# Altium schematic sheet charger.SchDoc(charger)
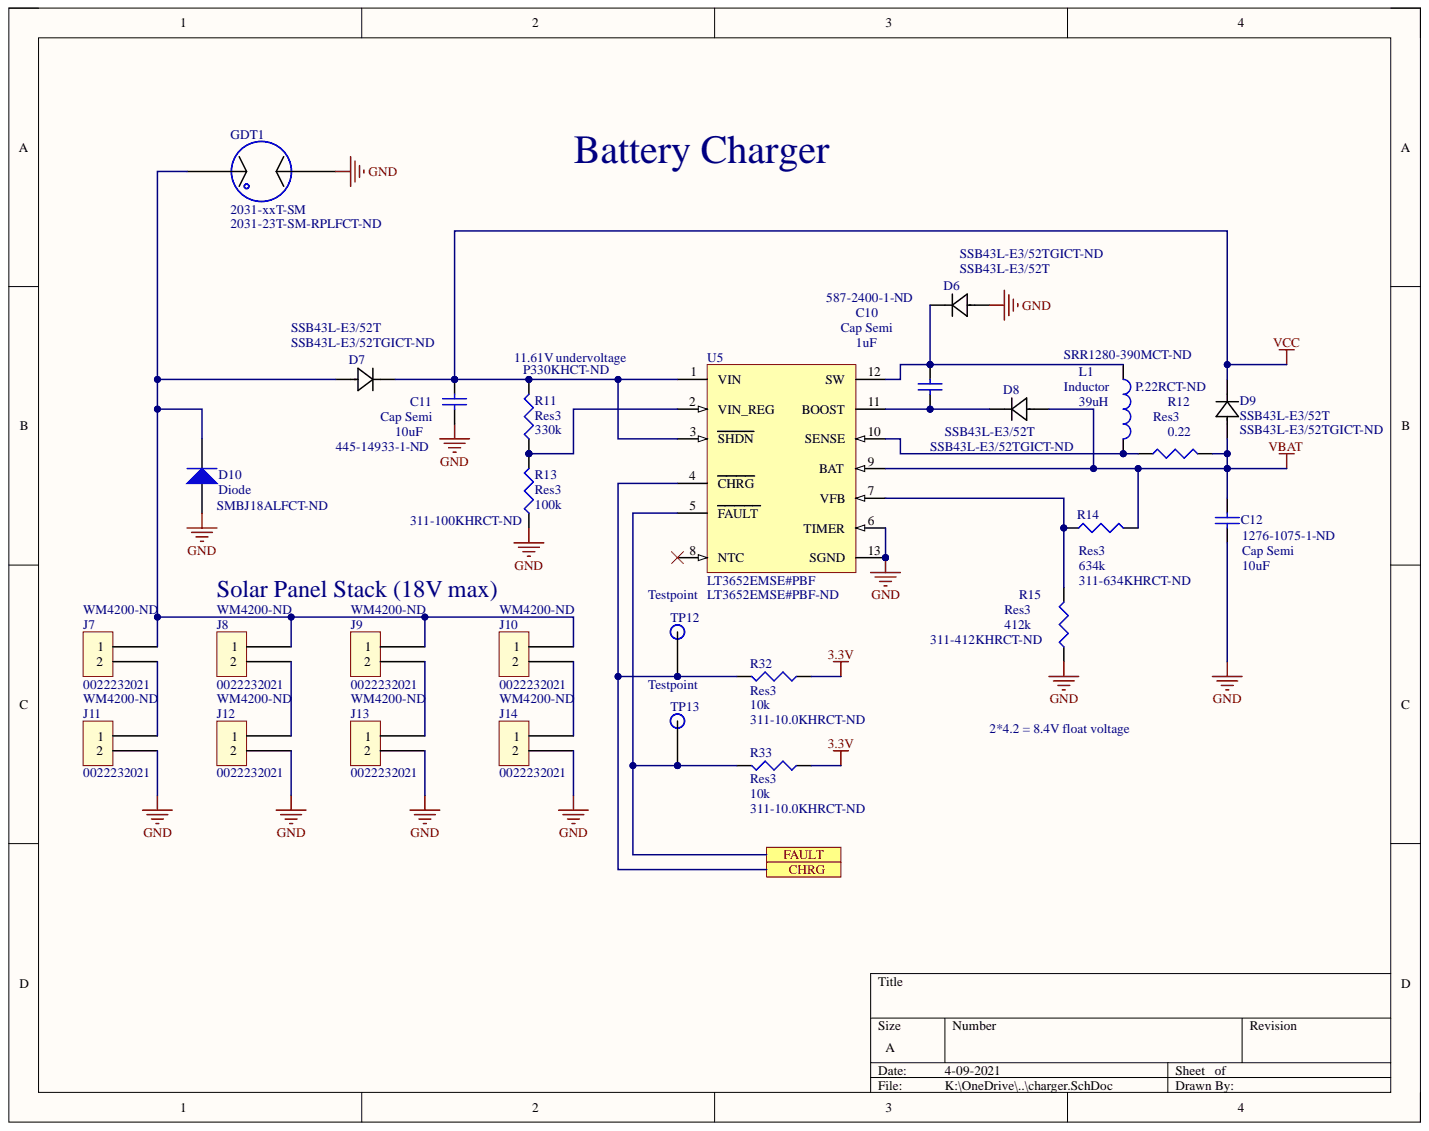
[diagram p0: charger.SchDoc]

## Parts
C10  Cap Semi  value 1uF  [1608[0603]]
C11  Cap Semi  value 10uF  Capacitance: 10uF  Supplier Part Number 1: 445-14933-1-ND  [C1210]
C12  Cap Semi  value 10uF  Capacitance: 10uF  Supplier Part Number 1: 1276-1075-1-ND  [C1206]
D6  SSB43L-E3/52T  [DIODE_DO214AA_00]
D7  SSB43L-E3/52T  Supplier Part Number 1: SSB43L-E3/52TGICT-ND  [DIODE_DO214AA_00]
D8  SSB43L-E3/52T  Supplier Part Number 1: SSB43L-E3/52TGICT-ND  [DIODE_DO214AA_00]
D9  SSB43L-E3/52T  Supplier Part Number 1: SSB43L-E3/52TGICT-ND  [DIODE_DO214AA_00]
D10  Diode  Supplier Part Number 1: SMBJ18ALFCT-ND  [smbj]
GDT1  2031-xxT-SM  Supplier Part Number 1: 2031-23T-SM-RPLFCT-ND  [2031-xxT-SM]
J7  0022232021  Supplier Part Number 1: WM4200-ND  [0022232021]
J8  0022232021  Supplier Part Number 1: WM4200-ND  [0022232021]
J9  0022232021  Supplier Part Number 1: WM4200-ND  [0022232021]
J10  0022232021  Supplier Part Number 1: WM4200-ND  [0022232021]
J11  0022232021  Supplier Part Number 1: WM4200-ND  [0022232021]
J12  0022232021  Supplier Part Number 1: WM4200-ND  [0022232021]
J13  0022232021  Supplier Part Number 1: WM4200-ND  [0022232021]
J14  0022232021  Supplier Part Number 1: WM4200-ND  [0022232021]
L1  Inductor  value 39uH  Inductance: 39uH  Supplier Part Number 1: SRR1280-390MCT-ND  [SRR1280-390M]
R11  Res3  value 330k  Supplier Part Number 1: P330KHCT-ND  [J1-0603]
R12  Res3  value 0.22  [RESC1206]
R13  Res3  value 100k  Supplier Part Number 1: 311-100KHRCT-ND  [J1-0603]
R14  Res3  value 634k  [J1-0603]
R15  Res3  value 412k  Supplier Part Number 1: 311-412KHRCT-ND  [J1-0603]
R32  Res3  value 10k  [J1-0603]
R33  Res3  value 10k  [J1-0603]
TP12  Testpoint  [testpoint]  (no BOM)
TP13  Testpoint  [testpoint]  (no BOM)
U5  LT3652EMSE#PBF  Supplier Part Number 1: LT3652EMSE#PBF-ND  [LT-MSE-12-EDP_M]

## Ports
CHRG, FAULT

## Nets
3.3V: R32.1 R33.1
GND: C11.1 C12.1 D6.2 D10.1 GDT1.1 J11.2 J12.2 J13.2 J14.2 R13.1 R15.1 U5.6(TIMER) U5.13(SGND)
VBAT: C12.2 D8.2 D9.2 R12.2 R14.2 U5.9(BAT)
VCC: C11.2 D7.1 D9.1 R11.2 U5.1(VIN) U5.3(SHDN)
CHRG: R32.2 TP12.1 U5.4(CHRG) ; port CHRG
FAULT: R33.2 TP13.1 U5.5(FAULT) ; port FAULT
NetC10_1: C10.1 D8.1 U5.11(BOOST)
NetC10_2: C10.2 D6.1 L1.1 U5.12(SW)
NetD7_2: D7.2 D10.2 GDT1.2 J7.1 J8.1 J9.1 J10.1
NetJ7_2: J7.2 J11.1
NetJ8_2: J8.2 J12.1
NetJ9_2: J9.2 J13.1
NetJ10_2: J10.2 J14.1
NetL1_2: L1.2 R12.1 U5.10(SENSE)
NetR11_1: R11.1 R13.2 U5.2(VIN_REG)
NetR14_1: R14.1 R15.2 U5.7(VFB)

Not connected: U5 8(NTC)

## Library data of the parts
C10 = Cap Semi: Capacitor (Semiconductor SIM Model); Manufacturer: Taiyo Yuden; Manufacturer Part Number: UMK107BJ105KA-T; Dielectric: X5R; Tolerance: 10%; Voltage Rating: 50V; Capacitance: 1uF; Case Code (Imperial): 0603; Case Code (Metric): 1608; Case/Package: 0603; Component Kind: Standard; ComponentLink1Description: https://datasheet.ciiva.com/5744/1697715-5744913.pdf?src-supplier=Farnell; ComponentLink1URL: https://datasheet.ciiva.com/5744/1697715-5744913.pdf?src-supplier=Farnell; ComponentLink2Description: https://datasheet.ciiva.com/11601/umk107bj105ka-t-ss-11601811.pdf?src-supplier=Avnet; ComponentLink2URL: https://datasheet.ciiva.com/11601/umk107bj105ka-t-ss-11601811.pdf?src-supplier=Avnet; ComponentLink3Description: https://datasheet.ciiva.com/15922/336umk107bj105ka-t-15922611.pdf?src-supplier=Onlinecomponents.com; ComponentLink3URL: https://datasheet.ciiva.com/15922/336umk107bj105ka-t-15922611.pdf?src-supplier=Onlinecomponents.com; ComponentLink4Description: https://datasheet.ciiva.com/134/umk107bj105ka-t-ss-134740.pdf?src-supplier=Digi-Key; ComponentLink4URL: https://datasheet.ciiva.com/134/umk107bj105ka-t-ss-134740.pdf?src-supplier=Digi-Key; ComponentLink5Description: https://datasheet.ciiva.com/30199/43umk107bj105ka-t-30199791.pdf?src-supplier=Onlinecomponents.com; ComponentLink5URL: https://datasheet.ciiva.com/30199/43umk107bj105ka-t-30199791.pdf?src-supplier=Onlinecomponents.com; ComponentLink6Description: https://datasheet.ciiva.com/22694/1697715-22694278.pdf?src-supplier=Element14; ComponentLink6URL: https://datasheet.ciiva.com/22694/1697715-22694278.pdf?src-supplier=Element14; ComponentLink7Description: https://datasheet.ciiva.com/7975/1697715-7975608.pdf?src-supplier=Element14; ComponentLink7URL: https://datasheet.ciiva.com/7975/1697715-7975608.pdf?src-supplier=Element14; ComponentLink8Description: https://datasheet.ciiva.com/7975/mlcc-all-e-7975898.pdf?src-supplier=Element14; ComponentLink8URL: https://datasheet.ciiva.com/7975/mlcc-all-e-7975898.pdf?src-supplier=Element14; ComponentLink9Description: https://datasheet.ciiva.com/5427/mlcc-all-e-541559-5427036.pdf?src-supplier=Mouser; ComponentLink9URL: https://datasheet.ciiva.com/5427/mlcc-all-e-541559-5427036.pdf?src-supplier=Mouser; Contact Plating: Nickel; Depth: 0.8mm; Halogen Free: Halogen Free; Height: 0.8mm; LatestRevisionDate: 29-May-2009; LatestRevisionNote: IPC-7351 Footprint Added.; Length: 1.6mm; Library Name: Miscellaneous Devices.IntLib; Max Operating Temperature: 85°C; Min Operating Temperature: -55°C; Mount: Surface Mount; Number of Pins: 2; Package Quantity: 1; PackageDescription: Chip Capacitor; PackageReference: C1206; Packaging: Tape and Reel; Pricing 1: 1 = 0.21000, 10 = 0.14600, 100 = 0.06880, 500 = 0.04822, 1000 = 0.03993 (USD); Published: 8-Jun-2000; Radiation Hardening: No; REACH SVHC: Unknown; RoHS: Yes; Signal Integrity: Cap Semi; Simulation: CAP; Stock 1: 3042440; Supplier 1: Digi-Key; Supplier Part Number 1: 587-2400-1-ND; Termination: SMD/SMT; Thickness: 0.035inch; Voltage Rating (DC): 50V; Width: 0.031inch; Publisher: Altium Limited
C11 = Cap Semi: Capacitor (Semiconductor SIM Model); Manufacturer: TDK; Manufacturer Part Number: C3225X7R1H106M250AC; Dielectric: X7R; Dielectric Material: Ceramic; Tolerance: 20%; Voltage Rating: 50V; Case Code (Imperial): 1210; Case Code (Metric): 3225; Case/Package: 1210; Component Kind: Standard; ComponentLink1Description: https://datasheet.ciiva.com/24216/cga6p3x7s1h106k250ae-266179-24216129.pdf?src-supplier=Mouser; ComponentLink1URL: https://datasheet.ciiva.com/24216/cga6p3x7s1h106k250ae-266179-24216129.pdf?src-supplier=Mouser; ComponentLink2Description: https://datasheet.ciiva.com/46446/1863333-46446041.pdf?src-supplier=Element14; ComponentLink2URL: https://datasheet.ciiva.com/46446/1863333-46446041.pdf?src-supplier=Element14; ComponentLink3Description: https://datasheet.ciiva.com/46446/1863333-46446042.pdf?src-supplier=Element14; ComponentLink3URL: https://datasheet.ciiva.com/46446/1863333-46446042.pdf?src-supplier=Element14; ComponentLink4Description: http://www.farnell.com/datasheets/2291921.pdf?src-supplier=Farnell; ComponentLink4URL: http://www.farnell.com/datasheets/2291921.pdf?src-supplier=Farnell; ComponentLink5Description: http://www.mouser.com/ds/2/400/tdk_mlcc_commercial_general_en-542463.pdf?src-supplier=Mouser; ComponentLink5URL: http://www.mouser.com/ds/2/400/tdk_mlcc_commercial_general_en-542463.pdf?src-supplier=Mouser; ComponentLink6Description: https://product.tdk.com/info/en/documents/chara_sheet/C3225X7R1H106M250AC.pdf?src-supplier=Digi-Key; ComponentLink6URL: https://product.tdk.com/info/en/documents/chara_sheet/C3225X7R1H106M250AC.pdf?src-supplier=Digi-Key; ComponentLink7Description: http://product.tdk.com/capacitor/mlcc/en/documents/mlcc_commercial_general_en.pdf?src-supplier=Avnet; ComponentLink7URL: http://product.tdk.com/capacitor/mlcc/en/documents/mlcc_commercial_general_en.pdf?src-supplier=Avnet; ComponentLink8Description: http://www.farnell.com/datasheets/1913358.pdf?src-supplier=Element14; ComponentLink8URL: http://www.farnell.com/datasheets/1913358.pdf?src-supplier=Element14; ComponentLink9Description: http://www.farnell.com/datasheets/2291921.pdf?src-supplier=Newark; ComponentLink9URL: http://www.farnell.com/datasheets/2291921.pdf?src-supplier=Newark; Height: 2.5mm; Lead Free: Lead Free; Length: 0.126inch; Library Name: Miscellaneous Devices.IntLib; Max Operating Temperature: 125°C; Min Operating Temperature: -55°C; Mount: Surface Mount; Package Quantity: 1000; Packaging: Tape and Reel; Pricing 1: 1=1.57000, 10=1.24200, 100=0.93150, 500=0.70380 (USD); REACH SVHC: No SVHC; RoHS: Yes; Signal Integrity: Cap Semi; Simulation: CAP; Stock 1: 251613; Supplier 1: Digi-Key; Termination: SMD/SMT; Thickness: 0.11inch; Voltage Rating (DC): 50V; Weight: 0.000564oz; Width: 0.098inch
C12 = Cap Semi: Capacitor (Semiconductor SIM Model); Manufacturer: Samsung; Manufacturer Part Number: CL31A106KAHNNNE; Dielectric: X5R; Material: Ceramic; Tolerance: 10%; Voltage: 25V; Case Code (Imperial): 1206; Case Code (Metric): 3216; Case/Package: 1206; Component Kind: Standard; ComponentLink1Description: https://datasheet.ciiva.com/25710/cl31a106kahnnne-25710837.pdf?src-supplier=Digi-Key; ComponentLink1URL: https://datasheet.ciiva.com/25710/cl31a106kahnnne-25710837.pdf?src-supplier=Digi-Key; ComponentLink2Description: https://datasheet.ciiva.com/15195/getdatasheetpartid-355210-15195990.pdf?src-supplier=Verical; ComponentLink2URL: https://datasheet.ciiva.com/15195/getdatasheetpartid-355210-15195990.pdf?src-supplier=Verical; ComponentLink3Description: https://datasheet.ciiva.com/29201/452519-da-01-en-vs-kondensator-1206-29201124.pdf?src-supplier=Conrad; ComponentLink3URL: https://datasheet.ciiva.com/29201/452519-da-01-en-vs-kondensator-1206-29201124.pdf?src-supplier=Conrad; Contact Plating: Tin; Depth: 1.6mm; Height: 1.6mm; LatestRevisionDate: 29-May-2009; LatestRevisionNote: IPC-7351 Footprint Added.; Lead Free: Lead Free; Length: 3.2mm; Library Name: Miscellaneous Devices.IntLib; Max Operating Temperature: 85°C; Min Operating Temperature: -55°C; Mount: Surface Mount; PackageDescription: Chip Capacitor; PackageReference: C1206; Packaging: Tape and Reel; Pricing 1: 1 = 0.22000, 10 = 0.15000, 100 = 0.07600, 500 = 0.05200, 1000 = 0.04600 (USD); Published: 8-Jun-2000; Radiation Hardening: No; RoHS: Yes; Signal Integrity: Cap Semi; Simulation: CAP; Stock 1: 1234131; Supplier 1: Digi-Key; Thickness: 0.071inch; Voltage Rating: 25V; Voltage Rating (DC): 25V; Width: 0.063inch; Publisher: Altium Limited
D6-D9 = SSB43L-E3/52T: Manufacturer: Vishay Semiconductors; Average Rectified Current: 4A; Element Configuration: Single; Forward Voltage: 450mV; Max Forward Surge Current (Ifsm): 100A; Max Repetitive Reverse Voltage (Vrrm): 30V; Max Reverse Leakage Current: 600uA; Polarity: Standard; Case/Package: SMB; Component Kind: Standard; ComponentLink1Description: https://datasheet.ciiva.com/7962/64541-7962449.pdf?src-supplier=Element14; ComponentLink1URL: https://datasheet.ciiva.com/7962/64541-7962449.pdf?src-supplier=Element14; ComponentLink2Description: https://datasheet.ciiva.com/14634/0900766b80dd0508-14634162.pdf?src-supplier=Allied+Electronics; ComponentLink2URL: https://datasheet.ciiva.com/14634/0900766b80dd0508-14634162.pdf?src-supplier=Allied+Electronics; ComponentLink3Description: https://datasheet.ciiva.com/4245/ssb43l-4245635.pdf?src-supplier=Arrow; ComponentLink3URL: https://datasheet.ciiva.com/4245/ssb43l-4245635.pdf?src-supplier=Arrow; ComponentLink4Description: https://datasheet.ciiva.com/15224/getdatasheetpartid-99263-15224680.pdf?src-supplier=Verical; ComponentLink4URL: https://datasheet.ciiva.com/15224/getdatasheetpartid-99263-15224680.pdf?src-supplier=Verical; ComponentLink5Description: https://datasheet.ciiva.com/18434/70217334-18434422.pdf?src-supplier=Allied+Electronics; ComponentLink5URL: https://datasheet.ciiva.com/18434/70217334-18434422.pdf?src-supplier=Allied+Electronics; Contact Plating: Tin; Current Rating: 4A; Description: SSB43L-E3/52T; Forward Current: 4A; Height: 0.095inch; Lead Free: Lead Free; Length: 4.7mm; Library Name: vishay.IntLib; Manufacturer Part Number: SSB43L-E3/52T; Max Operating Temperature: 150°C; Max Reverse Voltage (DC): 30V; Max Surge Current: 100A; Min Operating Temperature: -65°C; Mount: Surface Mount; Number of Pins: 2; Output Current: 4A; Package Quantity: 1; Packaging: Tape and Reel; Peak Non-Repetitive Surge Current: 100A; Peak Reverse Current: 600uA; Pricing 1: 1 = 0.58000, 10 = 0.49300, 100 = 0.36800 (USD); Radiation Hardening: No; REACH SVHC: Unknown; RoHS: Yes; Stock 1: 195068; Supplier 1: Digi-Key; Voltage Rating (DC): 30V; Width: 3.8mm
  D6: Supplier Part Number 1: SSB43L-E3/52TGICT-ND
D10 = Diode: Default Diode; Manufacturer: Littelfuse; Manufacturer Part Number: SMBJ18A; Breakdown Voltage: 20V; Clamping Voltage: 29.2V; Direction: Unidirectional; Element Configuration: Single; Leakage Current: 1uA; Max Breakdown Voltage: 22.1V; Max Power Dissipation: 5W; Max Surge Current: 20.6A; Number of Channels: 1; Operating Supply Voltage: 18V; Peak Pulse Power: 600W; Power Line Protection: No; Test Current: 1mA; Case/Package: SMB; Component Kind: Standard; ComponentLink1Description: http://www.bourns.com/data/global/pdfs/SMBJ.pdf; ComponentLink1URL: http://www.bourns.com/data/global/pdfs/SMBJ.pdf; ComponentLink2Description: https://datasheet.ciiva.com/25624/0900766b810f4eeb-25624683.pdf?src-supplier=RSComponents; ComponentLink2URL: https://datasheet.ciiva.com/25624/0900766b810f4eeb-25624683.pdf?src-supplier=RSComponents; ComponentLink3Description: https://datasheet.ciiva.com/15143/getdatasheetpartid-158332-15143823.pdf?src-supplier=Verical; ComponentLink3URL: https://datasheet.ciiva.com/15143/getdatasheetpartid-158332-15143823.pdf?src-supplier=Verical; ComponentLink4Description: https://datasheet.ciiva.com/331/littelfuse-tvs-diode-smbj-datasheet-pdf-331232.pdf?src-supplier=Digi-Key; ComponentLink4URL: https://datasheet.ciiva.com/331/littelfuse-tvs-diode-smbj-datasheet-pdf-331232.pdf?src-supplier=Digi-Key; ComponentLink5Description: https://datasheet.ciiva.com/8062/littelfuse-tvs-diode-smbj-8062311.pdf?src-supplier=Element14; ComponentLink5URL: https://datasheet.ciiva.com/8062/littelfuse-tvs-diode-smbj-8062311.pdf?src-supplier=Element14; ComponentLink6Description: https://datasheet.ciiva.com/331/littelfuse-tvs-diode-smbj-datasheet-pdf-331230.pdf?src-supplier=Digi-Key; ComponentLink6URL: https://datasheet.ciiva.com/331/littelfuse-tvs-diode-smbj-datasheet-pdf-331230.pdf?src-supplier=Digi-Key; ComponentLink7Description: https://datasheet.ciiva.com/331/littelfuse-tvs-diode-smbj-datasheet-pdf-331231.pdf?src-supplier=Digi-Key; ComponentLink7URL: https://datasheet.ciiva.com/331/littelfuse-tvs-diode-smbj-datasheet-pdf-331231.pdf?src-supplier=Digi-Key; ComponentLink8Description: https://datasheet.ciiva.com/16354/littelfuse-tvs-diode-smbj-16354943.pdf?src-supplier=Future+Electronics; ComponentLink8URL: https://datasheet.ciiva.com/16354/littelfuse-tvs-diode-smbj-16354943.pdf?src-supplier=Future+Electronics; Composition: Zener; Height: 2.237mm; Lead Free: Lead Free; Length: 4.57mm; Library Name: Miscellaneous Devices.IntLib; Max Operating Temperature: 150°C; Max Reverse Leakage Current: 1uA; Min Breakdown Voltage: 20V; Min Operating Temperature: -65°C; Mount: Surface Mount; Number of Elements: 1; Number of Pins: 2; Number of Unidirectional Channels: 1; Package Quantity: 3000; Packaging: Tape and Reel; Peak Pulse Current: 20.6A; Polarity: Unidirectional; Power Dissipation: 600W; Pricing 1: 1 = 0.53000, 10 = 0.41600, 25 = 0.35080, 100 = 0.28550, 250 = 0.23660, 500 = 0.19580, 1000 = 0.14685 (USD); Radiation Hardening: No; REACH SVHC: Unknown; Reverse Breakdown Voltage: 20V; Reverse Standoff Voltage: 18V; RoHS: Yes; Signal Integrity: Diode; Simulation: DIODE; Stock 1: 15394; Supplier 1: Digi-Key; Termination: SMD/SMT; Voltage Rating (DC): 18V; Width: 3.94mm
GDT1 = 2031-xxT-SM: Gas discharge tube; Manufacturer: Bourns Inc.; Manufacturer Part Number: 2031-23T-SM-RPLF; Fail Short: No; Impulse Discharge Current (8/20µs): 150A (10/700µs); Number of Poles: 2; Voltage - DC Spark Over (Nom): 185V; Category: Circuit Protection; Component Kind: Standard; ComponentLink1Description: http://www.bourns.com/data/global/pdfs/2031-xxT.pdf; ComponentLink1URL: http://www.bourns.com/data/global/pdfs/2031-xxT.pdf; Current - AC Discharge (Nom): -; Library Name: bourns.IntLib; Mounting Type: Surface Mount; Package / Case: 2-SMD Cylinder Square End; Packaging: Cut Tape (CT); Pricing 1: 1=1.44, 10=1.307, 25=1.2644, 50=1.0836, 100=0.903, 250=0.8772, 500=0.7439 (USD); RoHS: RoHS Compliant; Stock 1: 847; Supplier 1: Digi-Key; Tolerance: -
J7-J14 = 0022232021: Manufacturer: Molex; Approvals: UL; Contact Resistance: 10mR; Current Rating: 4A; Gender: Male; Glow Wire Compliant: Non-compliant; Insulation Resistance: 500GR; Number of Circuits: 2; Pitch: 2.54mm; Voltage Rating: 250V; Color: White; Component Kind: Standard; ComponentLink1Description: https://datasheet.ciiva.com/19454/232266-19454304.pdf?src-supplier=Jameco; ComponentLink1URL: https://datasheet.ciiva.com/19454/232266-19454304.pdf?src-supplier=Jameco; ComponentLink2Description: https://datasheet.ciiva.com/22683/1834442-22683561.pdf?src-supplier=Element14; ComponentLink2URL: https://datasheet.ciiva.com/22683/1834442-22683561.pdf?src-supplier=Element14; ComponentLink3Description: https://datasheet.ciiva.com/5150/0022232021-pcb-headers-227096-5150681.pdf?src-supplier=Mouser; ComponentLink3URL: https://datasheet.ciiva.com/5150/0022232021-pcb-headers-227096-5150681.pdf?src-supplier=Mouser; ComponentLink4Description: https://datasheet.ciiva.com/11746/0900766b80d8f4d1-11746058.pdf?src-supplier=RSComponents; ComponentLink4URL: https://datasheet.ciiva.com/11746/0900766b80d8f4d1-11746058.pdf?src-supplier=RSComponents; ComponentLink5Description: https://datasheet.ciiva.com/30253/114200022232021-pcb-headers-30253002.pdf?src-supplier=Onlinecomponents.com; ComponentLink5URL: https://datasheet.ciiva.com/30253/114200022232021-pcb-headers-30253002.pdf?src-supplier=Onlinecomponents.com; ComponentLink6Description: https://datasheet.ciiva.com/16658/0022232021-pcb-headers-16658167.pdf?src-supplier=Avnet+Europe; ComponentLink6URL: https://datasheet.ciiva.com/16658/0022232021-pcb-headers-16658167.pdf?src-supplier=Avnet+Europe; ComponentLink7Description: https://datasheet.ciiva.com/18453/70190606-18453638.pdf?src-supplier=Allied+Electronics; ComponentLink7URL: https://datasheet.ciiva.com/18453/70190606-18453638.pdf?src-supplier=Allied+Electronics; ComponentLink8Description: https://datasheet.ciiva.com/9468/0022232021-pcb-headers-9468476.pdf?src-supplier=Avnet; ComponentLink8URL: https://datasheet.ciiva.com/9468/0022232021-pcb-headers-9468476.pdf?src-supplier=Avnet; Contact Material: Brass; Contact Plating: Tin; Depth: 6.35mm; Description: 0022232021; Flammability Rating: UL94 V-0; Halogen Free: Low Halogen; Height: 10.67mm; Housing Color: White; Housing Material: Nylon; Lead Free: Lead Free; Length: 5.08mm; Library Name: molex.IntLib; Manufacturer Part Number: 22-23-2021; Max Current Rating: 4A; Max Operating Temperature: 75°C; Min Operating Temperature: 0°C; Mount: Through Hole; Number of Contacts: 2; Number of Positions: 2; Number of Rows: 1; Orientation: Straight; Package Quantity: 3000; Packaging: Bulk; Pricing 1: 1=0.17000, 10=0.16100, 100=0.11150, 500=0.09438, 1000=0.07722, 5000=0.06864, 10000=0.06221 (USD); Radiation Hardening: No; REACH SVHC: Unknown; RoHS: Yes; Stock 1: 76471; Supplier 1: Digi-Key; Termination: Through Hole; Voltage Rating (DC): 250V
L1 = Inductor: Manufacturer: Bourns; Code_IEC: 1005; Core Material: Ferrite; Current Rating: 3.2A; DC Resistance (DCR): 70mR; Frequency: 8.5MHz; Inductance Tolerance: 20%; Material: Steel; Q Factor: 24; Saturation Current: 3.1A; Shielding: Shielded; Test Frequency: 2.52MHz; Case/Package: Nonstandard; Code_JEITA: 0402; Component Kind: Standard; ComponentLink1Description: https://datasheet.ciiva.com/1062/srr1280-1062601.pdf?src-supplier=Digi-Key; ComponentLink1URL: https://datasheet.ciiva.com/1062/srr1280-1062601.pdf?src-supplier=Digi-Key; ComponentLink2Description: https://datasheet.ciiva.com/1062/srr1280-1062602.pdf?src-supplier=Digi-Key; ComponentLink2URL: https://datasheet.ciiva.com/1062/srr1280-1062602.pdf?src-supplier=Digi-Key; ComponentLink3Description: https://datasheet.ciiva.com/48684/srr1280-48684942.pdf?src-supplier=Avnet; ComponentLink3URL: https://datasheet.ciiva.com/48684/srr1280-48684942.pdf?src-supplier=Avnet; Composition: Wirewound; DC Current: 3.2A; Depth: 12.8mm; Description: Inductor; Height: 7.5mm; Height - Seated (Max): 0.315inch; LatestRevisionDate: 29-May-2009; LatestRevisionNote: IPC-7351 Footprint Added.; Length: 12.5mm; Library Name: Miscellaneous Devices.IntLib; Manufacturer Part Number: SRR1280-390M; Max DC Current: 3.2A; Max Operating Temperature: 125°C; Military Standard: Not; Min Operating Temperature: -40°C; Mount: Surface Mount; Number of Pins: 2; Package Quantity: 400; PackageDescription: Chip Inductor; PackageReference: 0402-A; Packaging: Tape and Reel; Pricing 1: 1 = 1.25000, 10 = 1.15900, 100 = 0.83780 (USD); Published: 8-Jun-2000; REACH SVHC: No SVHC; Resistance: 70MR; RMS Current (Irms): 3.2A; RoHS: Yes; Self Resonant Frequency: 8.5MHz; Signal Integrity: Inductor; Simulation: INDUCTOR; Stock 1: 6267; Supplier 1: Digi-Key; Termination: SMD/SMT; Tolerance: 20%; Width: 12.5mm; Publisher: Altium Limited
R11 = Res3: Resistor; Manufacturer: Panasonic; Manufacturer Part Number: ERJ-3EKF3303V; Max Power Dissipation: 100mW; Resistance: 330kR; Temperature Coefficient: 100ppm/°C; Tolerance: 1%; Voltage Rating: 75V; Case Code (Imperial): 0603; Case Code (Metric): 1608; Case/Package: 0603; Component Kind: Standard; ComponentLink1Description: https://datasheet.ciiva.com/17206/aoa0000ce2-17206262.pdf?src-supplier=Avnet+Europe; ComponentLink1URL: https://datasheet.ciiva.com/17206/aoa0000ce2-17206262.pdf?src-supplier=Avnet+Europe; ComponentLink2Description: https://datasheet.ciiva.com/22673/1678782-22673287.pdf?src-supplier=Element14; ComponentLink2URL: https://datasheet.ciiva.com/22673/1678782-22673287.pdf?src-supplier=Element14; ComponentLink3Description: https://datasheet.ciiva.com/16766/70260748-16766613.pdf?src-supplier=Allied+Electronics; ComponentLink3URL: https://datasheet.ciiva.com/16766/70260748-16766613.pdf?src-supplier=Allied+Electronics; ComponentLink4Description: https://datasheet.ciiva.com/7836/aoa0000ce2-7836502.pdf?src-supplier=Element14; ComponentLink4URL: https://datasheet.ciiva.com/7836/aoa0000ce2-7836502.pdf?src-supplier=Element14; ComponentLink5Description: https://datasheet.ciiva.com/24164/aoa0000ce2-24164038.pdf?src-supplier=Mouser; ComponentLink5URL: https://datasheet.ciiva.com/24164/aoa0000ce2-24164038.pdf?src-supplier=Mouser; ComponentLink6Description: https://datasheet.ciiva.com/9117/aoa0000ce2-9117145.pdf?src-supplier=Avnet; ComponentLink6URL: https://datasheet.ciiva.com/9117/aoa0000ce2-9117145.pdf?src-supplier=Avnet; ComponentLink7Description: https://datasheet.ciiva.com/16781/70260748-16781705.pdf?src-supplier=Allied+Electronics; ComponentLink7URL: https://datasheet.ciiva.com/16781/70260748-16781705.pdf?src-supplier=Allied+Electronics; ComponentLink8Description: https://datasheet.ciiva.com/9182/aoa0000ce2-9182954.pdf?src-supplier=Avnet; ComponentLink8URL: https://datasheet.ciiva.com/9182/aoa0000ce2-9182954.pdf?src-supplier=Avnet; ComponentLink9Description: https://datasheet.ciiva.com/30247/aoa0000ce2-30247322.pdf?src-supplier=Onlinecomponents.com; ComponentLink9URL: https://datasheet.ciiva.com/30247/aoa0000ce2-30247322.pdf?src-supplier=Onlinecomponents.com; ComponentLink10Description: https://datasheet.ciiva.com/22687/1678782-22687435.pdf?src-supplier=Element14; ComponentLink10URL: https://datasheet.ciiva.com/22687/1678782-22687435.pdf?src-supplier=Element14; Composition: Thick Film; Contact Plating: Tin; Depth: 0.8mm; Features: Automotive AEC-Q200; Height: 0.022inch; LatestRevisionDate: 29-May-2009; LatestRevisionNote: IPC-7351 Footprint Added.; Lead Free: Lead Free; Length: 1.6mm; Library Name: Miscellaneous Devices.IntLib; Max Operating Temperature: 155°C; Military Standard: Not; Min Operating Temperature: -55°C; Mount: Surface Mount; Number of Pins: 2; Number of Terminations: 2; Package Quantity: 1; PackageDescription: Chip Resistor; PackageReference: J1-0603; Packaging: Tape and Reel; Power Rating: 100mW; Pricing 1: 1=0.10000, 10=0.06500, 100=0.02640, 1000=0.01186, 2500=0.01029 (USD); Published: 8-Jun-2000; Radiation Hardening: No; REACH SVHC: No SVHC; RoHS: Yes; Signal Integrity: Res; Simulation: RESISTOR; Stock 1: 836713; Supplier 1: Digi-Key; Termination: SMD/SMT; Voltage Rating (DC): 75V; Weight: 7.1E-05oz; Width: 0.8mm; Publisher: Altium Limited
R12 = Res3: Resistor; Manufacturer: Panasonic; Manufacturer Part Number: ERJ-8RQFR22V; Max Power Dissipation: 250mW; Resistance: 220mR; Temperature Coefficient: 250ppm/°C; Tolerance: 1%; Case Code (Imperial): 1206; Case Code (Metric): 3216; Case/Package: 1206; Component Kind: Standard; ComponentLink1Description: https://datasheet.ciiva.com/11818/0900766b80eefa12-11818582.pdf?src-supplier=RSComponents; ComponentLink1URL: https://datasheet.ciiva.com/11818/0900766b80eefa12-11818582.pdf?src-supplier=RSComponents; ComponentLink2Description: https://datasheet.ciiva.com/28548/1790602-28548389.pdf?src-supplier=Element14; ComponentLink2URL: https://datasheet.ciiva.com/28548/1790602-28548389.pdf?src-supplier=Element14; ComponentLink3Description: https://datasheet.ciiva.com/16773/70068852-16773943.pdf?src-supplier=Allied+Electronics; ComponentLink3URL: https://datasheet.ciiva.com/16773/70068852-16773943.pdf?src-supplier=Allied+Electronics; ComponentLink4Description: https://datasheet.ciiva.com/28694/1790602-28694263.pdf?src-supplier=Element14; ComponentLink4URL: https://datasheet.ciiva.com/28694/1790602-28694263.pdf?src-supplier=Element14; ComponentLink5Description: https://datasheet.ciiva.com/24073/aoa0000ce3-72189-24073048.pdf?src-supplier=Mouser; ComponentLink5URL: https://datasheet.ciiva.com/24073/aoa0000ce3-72189-24073048.pdf?src-supplier=Mouser; ComponentLink6Description: https://datasheet.ciiva.com/9184/aoa0000ce3-9184313.pdf?src-supplier=Avnet; ComponentLink6URL: https://datasheet.ciiva.com/9184/aoa0000ce3-9184313.pdf?src-supplier=Avnet; ComponentLink7Description: https://datasheet.ciiva.com/16395/aoa0000ce3-16395226.pdf?src-supplier=Future+Electronics; ComponentLink7URL: https://datasheet.ciiva.com/16395/aoa0000ce3-16395226.pdf?src-supplier=Future+Electronics; ComponentLink8Description: https://datasheet.ciiva.com/17206/aoa0000ce3-17206890.pdf?src-supplier=Avnet+Europe; ComponentLink8URL: https://datasheet.ciiva.com/17206/aoa0000ce3-17206890.pdf?src-supplier=Avnet+Europe; ComponentLink9Description: https://datasheet.ciiva.com/6064/391509-6064715.pdf?src-supplier=Farnell; ComponentLink9URL: https://datasheet.ciiva.com/6064/391509-6064715.pdf?src-supplier=Farnell; ComponentLink10Description: https://datasheet.ciiva.com/16778/70068852-16778907.pdf?src-supplier=Allied+Electronics; ComponentLink10URL: https://datasheet.ciiva.com/16778/70068852-16778907.pdf?src-supplier=Allied+Electronics; ComponentLink11Description: https://datasheet.ciiva.com/30088/6aoa0000ce3-30088699.pdf?src-supplier=Onlinecomponents.com; ComponentLink11URL: https://datasheet.ciiva.com/30088/6aoa0000ce3-30088699.pdf?src-supplier=Onlinecomponents.com; ComponentLink12Description: https://datasheet.ciiva.com/7710/391509-7710844.pdf?src-supplier=Element14; ComponentLink12URL: https://datasheet.ciiva.com/7710/391509-7710844.pdf?src-supplier=Element14; ComponentLink13Description: https://datasheet.ciiva.com/6064/391509-6064717.pdf?src-supplier=Farnell; ComponentLink13URL: https://datasheet.ciiva.com/6064/391509-6064717.pdf?src-supplier=Farnell; ComponentLink14Description: https://datasheet.ciiva.com/31406/1790602-31406415.pdf?src-supplier=Farnell; ComponentLink14URL: https://datasheet.ciiva.com/31406/1790602-31406415.pdf?src-supplier=Farnell; Composition: Thick Film; Contact Plating: Tin; Depth: 1.6mm; Features: Automotive AEC-Q200; Height: 0.6mm; LatestRevisionDate: 29-May-2009; LatestRevisionNote: IPC-7351 Footprint Added.; Lead Free: Lead Free; Length: 3.2mm; Library Name: Miscellaneous Devices.IntLib; Max Operating Temperature: 125°C; Military Standard: Not; Min Operating Temperature: -55°C; Mount: Surface Mount; Number of Pins: 2; Number of Terminations: 2; Package Quantity: 1; PackageDescription: Chip Resistor; PackageReference: J1-0603; Packaging: Tape and Reel; Power Rating: 250mW; Pricing 1: 1 = 0.39000, 10 = 0.28300, 25 = 0.20920, 50 = 0.15420, 100 = 0.11320, 250 = 0.09348, 500 = 0.07708, 1000 = 0.05576 (USD); Published: 8-Jun-2000; Radiation Hardening: No; REACH SVHC: Unknown; RoHS: Yes; Signal Integrity: Res; Simulation: RESISTOR; Stock 1: 127343; Supplier 1: Digi-Key; Supplier Part Number 1: P.22RCT-ND; Termination: SMD/SMT; Width: 1.6mm; Publisher: Altium Limited
R13 = Res3: Resistor; Manufacturer: Yageo; Manufacturer Part Number: RC0603FR-07100KL; Power Rating: 100mW; Resistance: 100kR; Temperature Coefficient: 100ppm/°C; Tolerance: 1%; Voltage Rating: 50V; Working Voltage: 75V; Case Code (Imperial): 0603; Case Code (Metric): 1608; Case/Package: 0603; Component Kind: Standard; ComponentLink1Description: https://datasheet.ciiva.com/11808/0900766b80f96399-11808107.pdf?src-supplier=RSComponents; ComponentLink1URL: https://datasheet.ciiva.com/11808/0900766b80f96399-11808107.pdf?src-supplier=RSComponents; ComponentLink2Description: https://datasheet.ciiva.com/7983/443971-7983593.pdf?src-supplier=Element14; ComponentLink2URL: https://datasheet.ciiva.com/7983/443971-7983593.pdf?src-supplier=Element14; ComponentLink3Description: https://datasheet.ciiva.com/46440/1868787-46440889.pdf?src-supplier=Element14; ComponentLink3URL: https://datasheet.ciiva.com/46440/1868787-46440889.pdf?src-supplier=Element14; ComponentLink4Description: https://datasheet.ciiva.com/7746/1738695-7746234.pdf?src-supplier=Element14; ComponentLink4URL: https://datasheet.ciiva.com/7746/1738695-7746234.pdf?src-supplier=Element14; ComponentLink5Description: https://datasheet.ciiva.com/7746/1738695-7746235.pdf?src-supplier=Element14; ComponentLink5URL: https://datasheet.ciiva.com/7746/1738695-7746235.pdf?src-supplier=Element14; ComponentLink6Description: https://datasheet.ciiva.com/22747/443971-22747516.pdf?src-supplier=Element14; ComponentLink6URL: https://datasheet.ciiva.com/22747/443971-22747516.pdf?src-supplier=Element14; Composition: Thick Film; Depth: 0.8mm; Height: 0.45mm; LatestRevisionDate: 29-May-2009; LatestRevisionNote: IPC-7351 Footprint Added.; Lead Free: Lead Free; Length: 1.6mm; Library Name: Miscellaneous Devices.IntLib; Max Operating Temperature: 155°C; Military Standard: Not; Min Operating Temperature: -55°C; Number of Pins: 2; Number of Terminations: 2; PackageDescription: Chip Resistor; PackageReference: J1-0603; Packaging: Tape and Reel; Pricing 1: 1=0.10000, 10=0.02400, 100=0.00970, 1000=0.00437, 2500=0.00379 (USD); Published: 8-Jun-2000; REACH SVHC: No SVHC; RoHS: Yes; Signal Integrity: Res; Simulation: RESISTOR; Stock 1: 48923364; Supplier 1: Digi-Key; Termination: SMD/SMT; Voltage Rating (DC): 50V; Width: 0.8mm; Publisher: Altium Limited
R14 = Res3: Resistor; Manufacturer: Yageo; Manufacturer Part Number: RC0603FR-07634KL; Max Power Dissipation: 100mW; Resistance: 634kR; Temperature Coefficient: 100ppm/°C; Tolerance: 1%; Value: 634k; Voltage Rating: 50V; Case Code (Imperial): 0603; Case Code (Metric): 1608; Case/Package: 0603; Component Kind: Standard; ComponentLink1Description: https://datasheet.ciiva.com/2455/pyu-r-int-thick-7-2455320.pdf?src-supplier=Digi-Key; ComponentLink1URL: https://datasheet.ciiva.com/2455/pyu-r-int-thick-7-2455320.pdf?src-supplier=Digi-Key; ComponentLink2Description: https://datasheet.ciiva.com/2455/pyu-r-int-thick-7-2455321.pdf?src-supplier=Digi-Key; ComponentLink2URL: https://datasheet.ciiva.com/2455/pyu-r-int-thick-7-2455321.pdf?src-supplier=Digi-Key; ComponentLink3Description: https://datasheet.ciiva.com/2455/pyu-r-int-thick-7-2455322.pdf?src-supplier=Digi-Key; ComponentLink3URL: https://datasheet.ciiva.com/2455/pyu-r-int-thick-7-2455322.pdf?src-supplier=Digi-Key; ComponentLink4Description: https://datasheet.ciiva.com/12560/rc0603-272842-12560941.pdf?src-supplier=Mouser; ComponentLink4URL: https://datasheet.ciiva.com/12560/rc0603-272842-12560941.pdf?src-supplier=Mouser; Composition: Thick Film; Depth: 0.8mm; Features: Moisture Resistant; Height: 0.022inch; LatestRevisionDate: 29-May-2009; LatestRevisionNote: IPC-7351 Footprint Added.; Lead Free: Lead Free; Length: 1.6mm; Library Name: Miscellaneous Devices.IntLib; Max Operating Temperature: 155°C; Military Standard: Not; Min Operating Temperature: -55°C; Number of Terminations: 2; Package Quantity: 1; PackageDescription: Chip Resistor; PackageReference: J1-0603; Packaging: Tape and Reel; Power Rating: 100mW; Pricing 1: 1 = 0.10000, 10 = 0.02400, 100 = 0.00970, 1000 = 0.00437, 2500 = 0.00379 (USD); Published: 8-Jun-2000; RoHS: Yes; Signal Integrity: Res; Simulation: RESISTOR; Stock 1: 347605; Supplier 1: Digi-Key; Supplier Part Number 1: 311-634KHRCT-ND; Termination: SMD/SMT; Voltage Rating (DC): 50V; Width: 0.031inch; Publisher: Altium Limited
R15 = Res3: Resistor; Manufacturer: Yageo; Manufacturer Part Number: RC0603FR-07412KL; Max Power Dissipation: 100mW; Resistance: 412kR; Temperature Coefficient: 100ppm/°C; Tolerance: 1%; Voltage Rating (DC): 50V; Case Code (Imperial): 0603; Case Code (Metric): 1608; Case/Package: 0603; Component Kind: Standard; ComponentLink1Description: https://datasheet.ciiva.com/2454/pyu-r-int-thick-7-2454489.pdf?src-supplier=Digi-Key; ComponentLink1URL: https://datasheet.ciiva.com/2454/pyu-r-int-thick-7-2454489.pdf?src-supplier=Digi-Key; ComponentLink2Description: https://datasheet.ciiva.com/2454/pyu-r-int-thick-7-2454490.pdf?src-supplier=Digi-Key; ComponentLink2URL: https://datasheet.ciiva.com/2454/pyu-r-int-thick-7-2454490.pdf?src-supplier=Digi-Key; ComponentLink3Description: https://datasheet.ciiva.com/2454/pyu-r-int-thick-7-2454491.pdf?src-supplier=Digi-Key; ComponentLink3URL: https://datasheet.ciiva.com/2454/pyu-r-int-thick-7-2454491.pdf?src-supplier=Digi-Key; Composition: Thick Film; Depth: 0.8mm; Features: Moisture Resistant; Height: 0.022inch; LatestRevisionDate: 29-May-2009; LatestRevisionNote: IPC-7351 Footprint Added.; Lead Free: Lead Free; Length: 0.063inch; Library Name: Miscellaneous Devices.IntLib; Max Operating Temperature: 155°C; Military Standard: Not; Min Operating Temperature: -55°C; Number of Terminations: 2; Package Quantity: 1; PackageDescription: Chip Resistor; PackageReference: J1-0603; Packaging: Tape and Reel; Pricing 1: 1 = 0.10000, 10 = 0.02400, 100 = 0.00970, 1000 = 0.00437, 2500 = 0.00379 (USD); Published: 8-Jun-2000; RoHS: Yes; Signal Integrity: Res; Simulation: RESISTOR; Stock 1: 153403; Supplier 1: Digi-Key; Width: 0.031inch; Publisher: Altium Limited
R32 R33 = Res3: Resistor; Manufacturer: Yageo; Manufacturer Part Number: RC0603FR-0710KL; Power Rating: 100mW; Resistance: 10kR; Temperature Coefficient: 100ppm/°C; Tolerance: 1%; Voltage Rating: 75V; Voltage Rating (DC): 50V; Case Code (Imperial): 0603; Case Code (Metric): 1608; Case/Package: 0603; Component Kind: Standard; ComponentLink1Description: https://datasheet.ciiva.com/7660/38590-7660789.pdf?src-supplier=Element14; ComponentLink1URL: https://datasheet.ciiva.com/7660/38590-7660789.pdf?src-supplier=Element14; ComponentLink2Description: https://datasheet.ciiva.com/15189/getdatasheetpartid-409289-15189591.pdf?src-supplier=Verical; ComponentLink2URL: https://datasheet.ciiva.com/15189/getdatasheetpartid-409289-15189591.pdf?src-supplier=Verical; ComponentLink3Description: https://datasheet.ciiva.com/46440/1868787-46440891.pdf?src-supplier=Element14; ComponentLink3URL: https://datasheet.ciiva.com/46440/1868787-46440891.pdf?src-supplier=Element14; ComponentLink4Description: https://datasheet.ciiva.com/7983/443971-7983596.pdf?src-supplier=Element14; ComponentLink4URL: https://datasheet.ciiva.com/7983/443971-7983596.pdf?src-supplier=Element14; ComponentLink5Description: https://datasheet.ciiva.com/7746/1738695-7746239.pdf?src-supplier=Element14; ComponentLink5URL: https://datasheet.ciiva.com/7746/1738695-7746239.pdf?src-supplier=Element14; ComponentLink6Description: https://datasheet.ciiva.com/22747/443971-22747519.pdf?src-supplier=Element14; ComponentLink6URL: https://datasheet.ciiva.com/22747/443971-22747519.pdf?src-supplier=Element14; Composition: Thick Film; Depth: 0.8mm; Height: 0.45mm; LatestRevisionDate: 29-May-2009; LatestRevisionNote: IPC-7351 Footprint Added.; Lead Free: Lead Free; Lead Pitch: 0.3mm; Length: 1.6mm; Library Name: Miscellaneous Devices.IntLib; Max Operating Temperature: 155°C; Military Standard: Not; Min Operating Temperature: -55°C; Number of Pins: 2; PackageDescription: Chip Resistor; PackageReference: J1-0603; Packaging: Tape and Reel; Pricing 1: 1 = 0.10000, 10 = 0.02400, 100 = 0.00970, 1000 = 0.00437, 2500 = 0.00379 (USD); Published: 8-Jun-2000; REACH SVHC: No SVHC; RoHS: Yes; Signal Integrity: Res; Simulation: RESISTOR; Stock 1: 90146690; Supplier 1: Digi-Key; Supplier Part Number 1: 311-10.0KHRCT-ND; Termination: SMD/SMT; Weight: 7.1E-05oz; Width: 0.8mm; Publisher: Altium Limited
TP12 TP13 = SMT Testpoint: Description: SMT Testpoint; Library Name: testpoints.IntLib
U5 = CMP-0473-00138-1: Power Tracking 2 A Battery Charger for Solar Power, 12-pin SOP (MSE-12-EDP), -40 to 125 degC, Pb-Free; Manufacturer: Linear Technology; Max Charge Current: 2A; Max Input Voltage: 32V; Max Output Voltage: 14.4V; Min Input Voltage: 4.95V; Number of Cells: 3; Number of Outputs: 1; Output Voltage: 4.2V; Vin Max(V): 32; Vin Min(V): 4.95; Case/Package: MSOP; Component Kind: Standard; ComponentLink1Description: Manufacturer URL; ComponentLink1URL: http://www.linear.com/; ComponentLink2Description: Datasheet; ComponentLink2URL: http://cds.linear.com/docs/en/datasheet/3652fd.pdf; ComponentLink3Description: Package Specification; ComponentLink3URL: http://cds.linear.com/docs/en/packaging/05081666_G_MSE12.pdf; ComponentLink4Description: https://datasheet.ciiva.com/15114/getdatasheetpartid-367678-15114671.pdf?src-supplier=Verical; ComponentLink4URL: https://datasheet.ciiva.com/15114/getdatasheetpartid-367678-15114671.pdf?src-supplier=Verical; ComponentLink5Description: https://datasheet.ciiva.com/1932/28522-1932092.pdf?src-supplier=Digi-Key; ComponentLink5URL: https://datasheet.ciiva.com/1932/28522-1932092.pdf?src-supplier=Digi-Key; ComponentLink6Description: https://datasheet.ciiva.com/1139/1692510-1139705.pdf?src-supplier=Farnell; ComponentLink6URL: https://datasheet.ciiva.com/1139/1692510-1139705.pdf?src-supplier=Farnell; DatasheetVersion: Rev. D; Energy Source: Solar; Height: 0.86mm; Length: 4.04mm; Library Name: Altium Content Vault; Manufacturer Part Number: LT3652EMSE#PBF; Max Operating Temperature: 125°C; Max Output Current: 2A; Max Supply Voltage: 32V; Min Operating Temperature: -40°C; Min Supply Voltage: 4.95V; Mounting Technology: Surface Mount; Number of Pins: 12; Package Quantity: 37; PackageDescription: 12-Pin Plastic MSOP, Exposed Die Pad, Body 4.039 x 3 mm, Pitch 0.65 mm; PackageReference: MSE-12-EDP; PackageVersion: Rev. G, 05/2013; Packaging: Rail/Tube; PartNumber: LT3652EMSE#PBF; Pricing 1: 1 = 7.40000, 25 = 4.91040, 100 = 4.06000, 250 = 3.85700, 500 = 3.77000 (USD); Radiation Hardening: No; REACH SVHC: No SVHC; RoHS: TRUE; Stock 1: 9413; Supplier 1: Digi-Key; Topology: Battery Charger; Width: 3mm
